# Altium schematic sheet Sheet_MotionDetect_v1.SchDoc(Sheet_MotionDetect_v1)
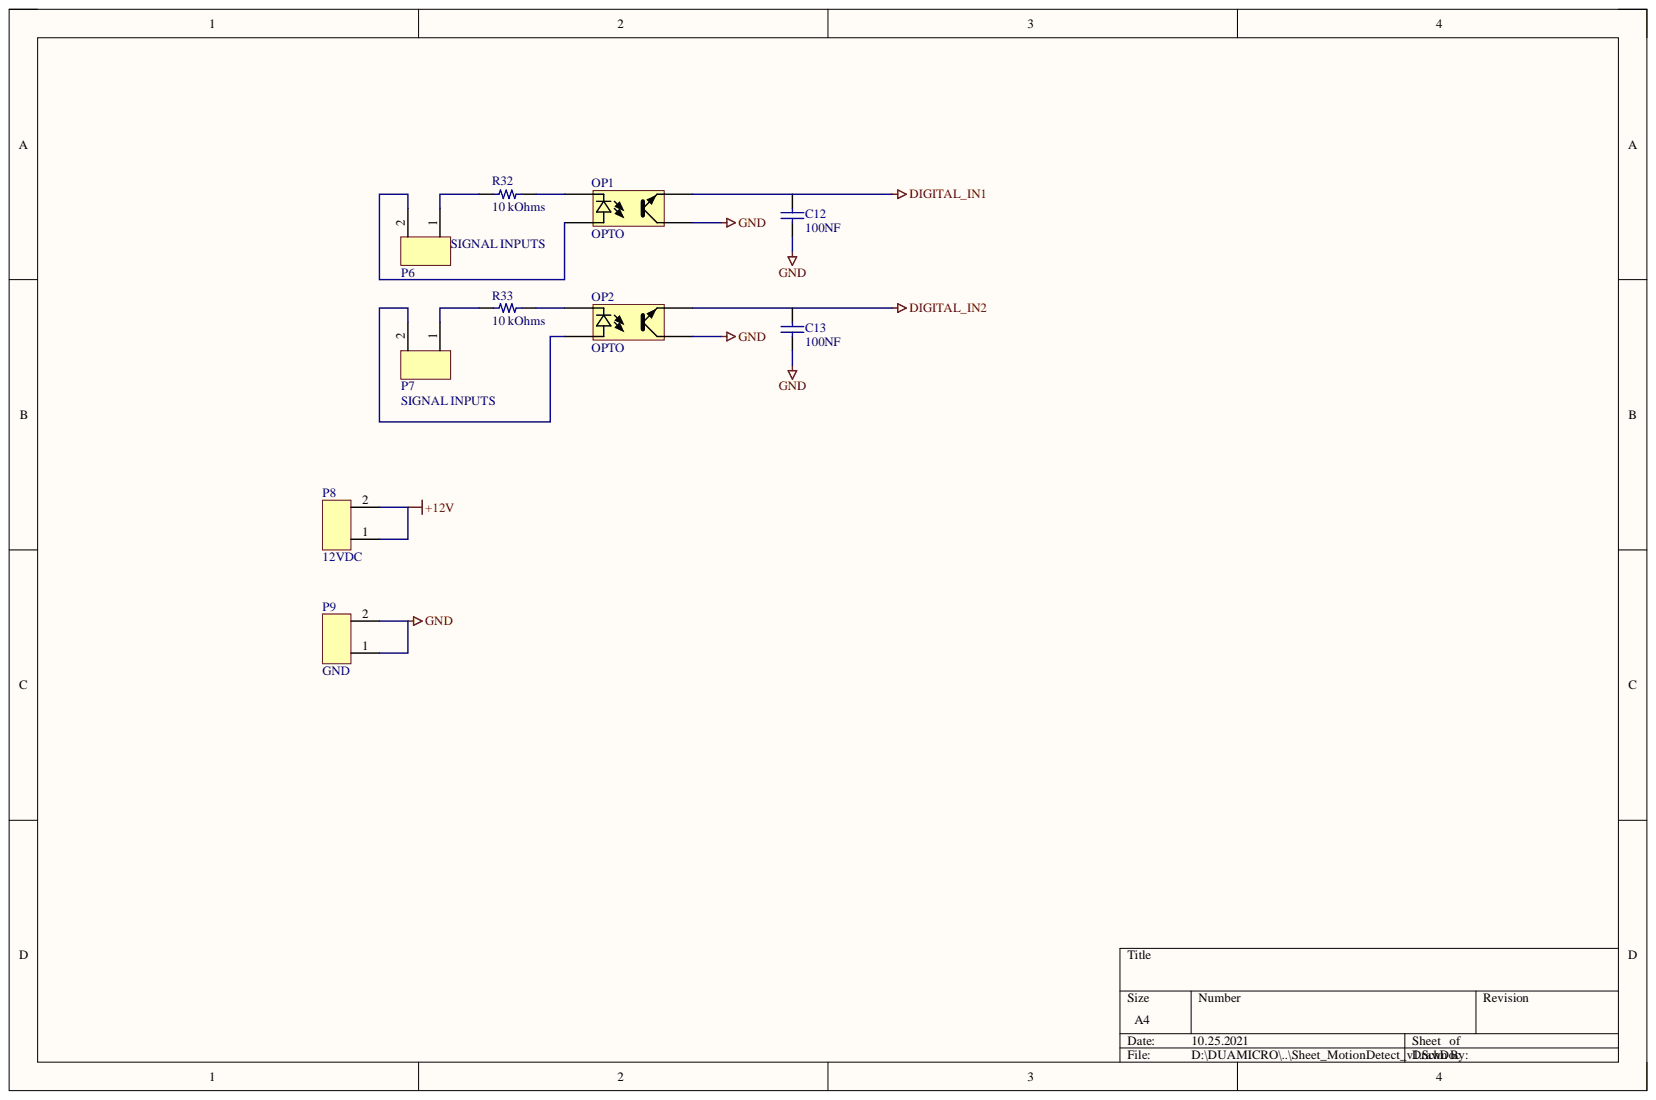
[diagram p0: Sheet_MotionDetect_v1.SchDoc]

## Parts
C12  100NF  [CAP 0603_1608]
C13  100NF  [CAP 0603_1608]
OP1  OPTO  [OPTO_SMD]
OP2  OPTO  [OPTO_SMD]
P6  SIGNAL INPUTS  [KLE2-3.50]
P7  SIGNAL INPUTS  [KLE2-3.50]
P8  12VDC  [KLE2-3.50]
P9  GND  [KLE2-3.50]
R32  10 kOhms  [RES 0603/1608]
R33  10 kOhms  [RES 0603/1608]

## Nets
+12V: P8.1 P8.2
DIGITAL_IN1: C12.2 OP1.E
DIGITAL_IN2: C13.2 OP2.E
GND: C12.1 C13.1 OP1.C OP2.C P9.1 P9.2
NetOP1_A: OP1.A P6.2
NetOP1_K: OP1.K R32.2
NetOP2_A: OP2.A P7.2
NetOP2_K: OP2.K R33.2
NetP6_1: P6.1 R32.1
NetP7_1: P7.1 R33.1

## Library data of the parts
C12 C13 = CAP CER 100NF 16V X7R 0603: Manufacturer: Samsung Electro-Mechanics; Part Number: CL10B104KO8NNNC; TemperatureRange: -55°C ~ 125°C; Tolerance: ±10%; Type: General Purpose; Component Kind: Standard; ComponentLink1Description: Datasheet; ComponentLink1URL: http://www.samsungsem.com/kr/support/product-search/mlcc/__icsFiles/afieldfile/2016/08/18/S_CL10B104KO8NNNC.pdf; ComponentLink2Description: Digi-Key listing; ComponentLink2URL: https://www.digikey.com/product-detail/en/samsung-electro-mechanics/CL10B104KO8NNNC/1276-1005-1-ND/3889091; Description: CAP CER 100NF 16V X7R 0603; Device Package: 0603 (1608 Metric); Price: $0.10000; Supplier 1: Digi-Key; Supplier Part Number 1: 1276-1005-1-ND; Value: 100NF; Voltage: 16V; Library Name: DualMicrolib.SchLib
OP1 OP2 = OPTO: TLP181; Component Kind: Standard; Library Name: Schlib (ASSAN).SchLib
P6-P9 = con2: KF2EDGR-Y-3.5-2P-1G; Component Kind: Standard; Library Name: SchlibTMS.SchLib
R32 R33 = Resistor_10K_0603_5%: RES SMD 10K OHM 5% 1/16W 0603; Manufacturer: Stackpole Electronics; Part Number: RMCF0603JG10K0; PowerRating: 0.1W, 1/10W; TemperatureCoefficient: ±100ppm/°C; TemperatureRange: -55°C ~ 125°C; Component Kind: Standard; ComponentLink1Description: Datasheet; ComponentLink1URL: http://www.seielect.com/catalog/sei-packaging.pdf; ComponentLink2Description: Digi-Key Listing; ComponentLink2URL: https://www.digikey.com/en/products/detail/stackpole-electronics-inc/rmcf0603jg10k0/1712133; Device Package: 0603 (1608 Metric); Price: $0.1000/min1; Supplier 1: DigiKey; Supplier 2: Digi-Key; Supplier Part Number 1: RMCF0603JG10K0; Supplier Part Number 2: RMCF0603JG10K0CT-ND; Tolerance: ±5%; Value: 10 kOhms; Library Name: DualMicrolib.SchLib
